# Altium schematic sheet Mechalino_Second_Layer.SchDoc(Mechalino_Second_Layer)
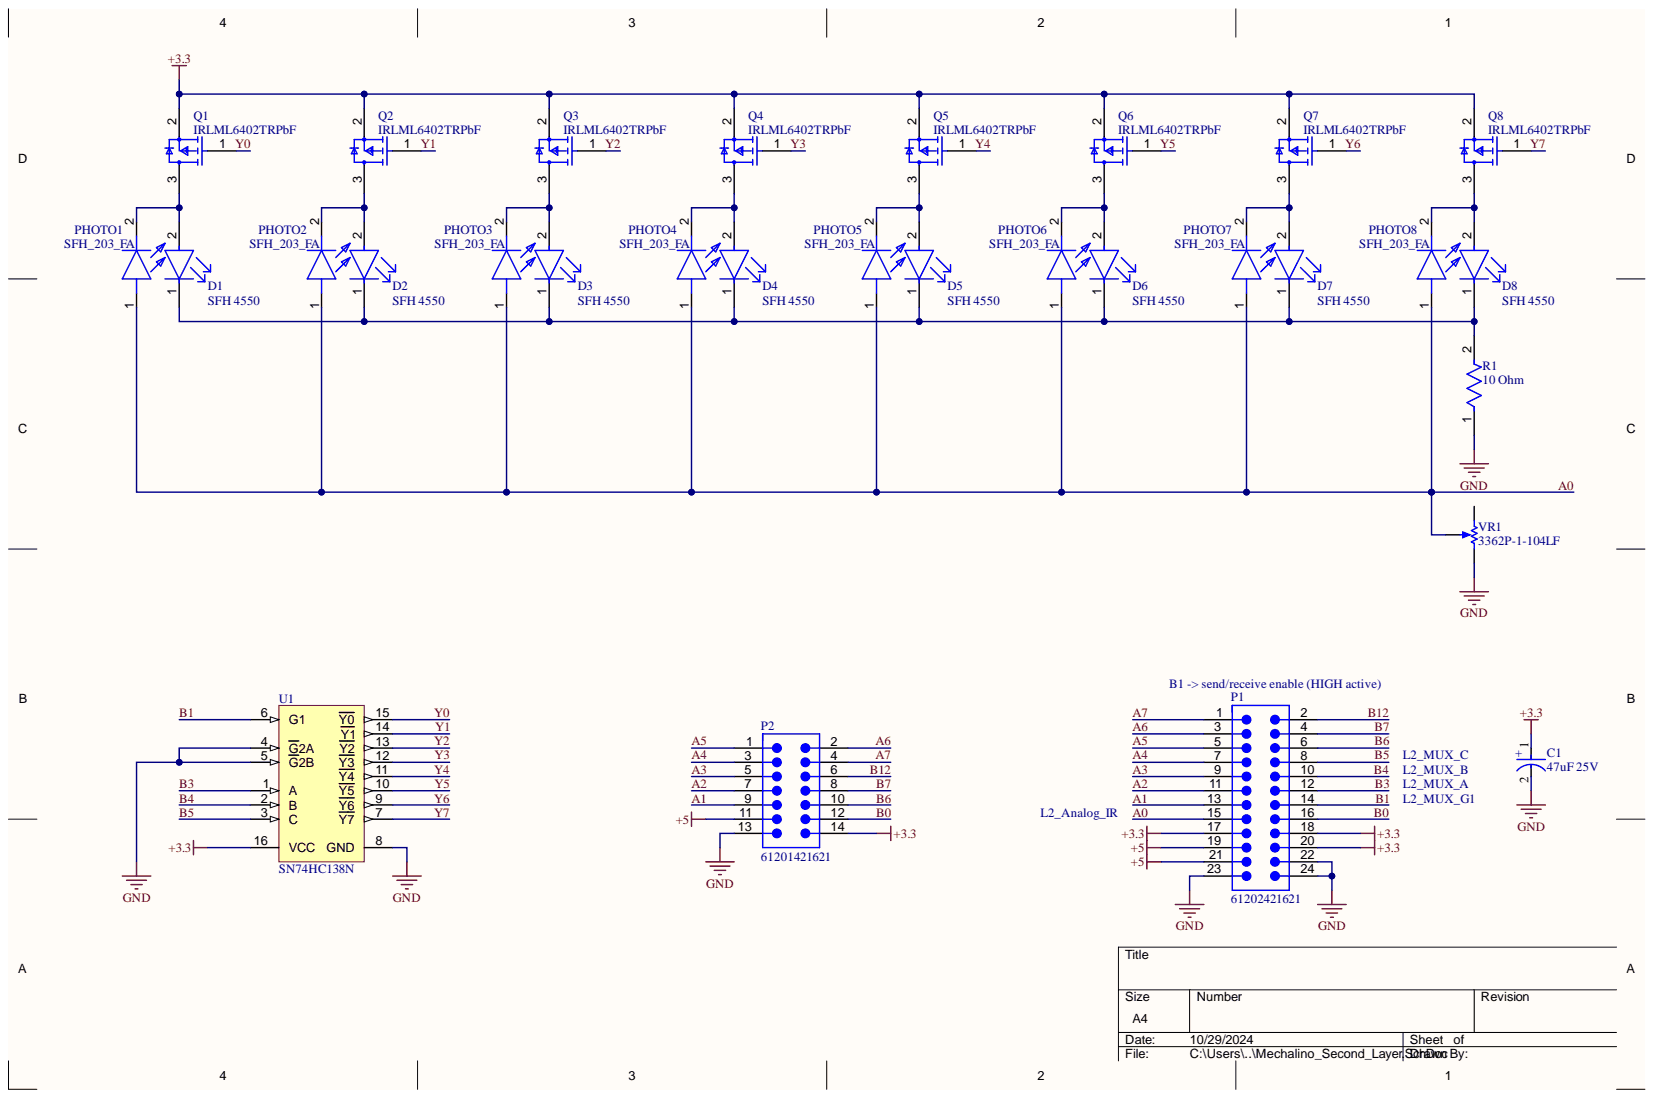
[diagram p0: Mechalino_Second_Layer.SchDoc]

## Parts
C1  47uF 25V  Capacitance: 47uF  [FP-FKD-MFG]
D1  SFH 4550  [FP-SFH_4550-MFG]
D2  SFH 4550  [FP-SFH_4550-MFG]
D3  SFH 4550  [FP-SFH_4550-MFG]
D4  SFH 4550  [FP-SFH_4550-MFG]
D5  SFH 4550  [FP-SFH_4550-MFG]
D6  SFH 4550  [FP-SFH_4550-MFG]
D7  SFH 4550  [FP-SFH_4550-MFG]
D8  SFH 4550  [FP-SFH_4550-MFG]
P1  61202421621  [61202421621]
P2  61201421621  [61201421621]
PHOTO1  SFH_203_FA  [SFH203FA]
PHOTO2  SFH_203_FA  [SFH203FA]
PHOTO3  SFH_203_FA  [SFH203FA]
PHOTO4  SFH_203_FA  [SFH203FA]
PHOTO5  SFH_203_FA  [SFH203FA]
PHOTO6  SFH_203_FA  [SFH203FA]
PHOTO7  SFH_203_FA  [SFH203FA]
PHOTO8  SFH_203_FA  [SFH203FA]
Q1  IRLML6402TRPbF  [FP-Micro3-MFG]
Q2  IRLML6402TRPbF  [FP-Micro3-MFG]
Q3  IRLML6402TRPbF  [FP-Micro3-MFG]
Q4  IRLML6402TRPbF  [FP-Micro3-MFG]
Q5  IRLML6402TRPbF  [FP-Micro3-MFG]
Q6  IRLML6402TRPbF  [FP-Micro3-MFG]
Q7  IRLML6402TRPbF  [FP-Micro3-MFG]
Q8  IRLML6402TRPbF  [FP-Micro3-MFG]
R1  10 Ohm  [FP-ESR25-IPC_B]
U1  SN74HC138N  [N0016A]
VR1  3362P-1-104LF  [BOUR-3362P]

## Nets
+3.3: C1.1 P1.17 P1.18 P1.20 P2.14 Q1.2 Q2.2 Q3.2 Q4.2 Q5.2 Q6.2 Q7.2 Q8.2 U1.16(VCC)
+5: P1.19 P1.21 P2.11
GND: C1.2 P1.22 P1.23 P1.24 P2.13 R1.1 U1.4(G2A) U1.5(G2B) U1.8(GND) VR1.3
A0: P1.15 PHOTO1.1 PHOTO2.1 PHOTO3.1 PHOTO4.1 PHOTO5.1 PHOTO6.1 PHOTO7.1 PHOTO8.1 VR1.2
A1: P1.13 P2.9
A2: P1.11 P2.7
A3: P1.9 P2.5
A4: P1.7 P2.3
A5: P1.5 P2.1
A6: P1.3 P2.2
A7: P1.1 P2.4
B0: P1.16 P2.12
B1: P1.14 U1.6(G1)
B3: P1.12 U1.1(A)
B4: P1.10 U1.2(B)
B5: P1.8 U1.3(C)
B6: P1.6 P2.10
B7: P1.4 P2.8
B12: P1.2 P2.6
Y0: Q1.1 U1.15(Y0)
Y1: Q2.1 U1.14(Y1)
Y2: Q3.1 U1.13(Y2)
Y3: Q4.1 U1.12(Y3)
Y4: Q5.1 U1.11(Y4)
Y5: Q6.1 U1.10(Y5)
Y6: Q7.1 U1.9(Y6)
Y7: Q8.1 U1.7(Y7)
NetD1_1: D1.1 D2.1 D3.1 D4.1 D5.1 D6.1 D7.1 D8.1 R1.2
NetD1_2: D1.2 PHOTO1.2 Q1.3
NetD2_2: D2.2 PHOTO2.2 Q2.3
NetD3_2: D3.2 PHOTO3.2 Q3.3
NetD4_2: D4.2 PHOTO4.2 Q4.3
NetD5_2: D5.2 PHOTO5.2 Q5.3
NetD6_2: D6.2 PHOTO6.2 Q6.3
NetD7_2: D7.2 PHOTO7.2 Q7.3
NetD8_2: D8.2 PHOTO8.2 Q8.3

Not connected: VR1 1

## Library data of the parts
C1 = CMP-05427-000093-1: CAP ALUM 47UF 20% 25V SMD; Dielectric Material: Aluminium; ESR (Equivalent Series Resistance): 360mR; Leakage Current: 3uA; Life (Hours): 2000Hour; Polarity: Polar; Ripple Current: 240mA; Case/Package: Radial; Component Kind: Standard; Construction: Cylindrical; Contact Plating: Tin; Diameter: 6.3mm; Height: 5.8mm; Height - Seated (Max): 0.24inch; Impedance: 360mR; Lead Free: Lead Free; Length: 5.8mm; Library Name: Altium Content Vault; Max Operating Temperature: 105°C; Min Operating Temperature: -55°C; Mount: Surface Mount; Number of Pins: 2; Package Quantity: 1000; Packaging: Tape and Reel; Radiation Hardening: No; REACH SVHC: No SVHC; Ripple Current (AC): 240mA; RoHS Compliant: Yes; Series: FK; Termination: SMD/SMT; Tolerance: 20%; Voltage: 25V; Voltage Rating: 25V; Voltage Rating (DC): 25V; Width: 7.8mm
D1-D8 = CMP-62016-000011-1: EMITTER IR 860NM 100MA RADIAL; Manufacturer: Osram Opto; Colour: Infrared; Forward Voltage: 1.5V; Led Orientation: Straight; Reverse Voltage: 5V; Viewing Angle: 6°; Automotive: No; Category: Diode; Component Kind: Standard; ComponentLink2Description: Datasheet; ComponentLink2URL: https://dammedia.osram.info/media/resource/hires/osram-dam-5580407/SFH%204550_EN.pdf; Device Class L1: Optoelectronics; Device Class L2: LEDs; Device Class L3: unset; Digikey Description: EMITTER IR 860NM 100MA RADIAL; Forward Current: 100mA; Lead Free: Yes; Library Name: Altium Content Vault; Manufacturer Part Number: SFH 4550; Mouser Description: Infrared Emitters - High Power Infrared 850 nm HALF ANGLE +/-3DEG; Octopart: https://octopart.com/sfh+4550-osram+opto-55410894; Package: SFH 4550; Peak Emmision Wavelength: 860nm; RoHS: TRUE; Temperature: -40°C to +100°C; Temperature Range High: +100°C; Temperature Range Low: -40°C
P1 = CMP-1502-00011-2: NexarPartId: 32855474; Connector Type: Male Pin; Contact Resistance: 20mΩ; Current Rating: 3A; Gender: Male; Insulation Resistance: 1GΩ; Number of Contacts: 24; Operating Supply Voltage: 250V; Pitch: 2.54mm; Color: Black; Component Kind: Standard; Contact Material: Copper; Contact Plating: Gold; Insulation Material: PBT; Lead Pitch: 2.54mm; Length: 38.14mm; Library Name: Altium Content Vault; Max Operating Temperature: 105°C; Min Operating Temperature: -40°C; Mount: Through Hole; Number of Pins: 24; Number of Positions: 24; Number of Rows: 2; Orientation: Vertical; REACH SVHC: Unknown; RoHS: Compliant; Row Spacing: 2.54mm; Termination: Solder
P2 = CMP-1502-00008-2: NexarPartId: 26603596; Connector Type: Male Pin; Contact Resistance: 20mΩ; Current Rating: 3A; Gender: Male; Insulation Resistance: 1GΩ; Number of Contacts: 14; Operating Supply Voltage: 250V; Pitch: 2.54mm; Color: Black; Component Kind: Standard; Contact Material: Copper; Contact Plating: Gold; Height: 9.25mm; Insulation Material: PBT; Lead Pitch: 2.54mm; Length: 25.44mm; Library Name: Altium Content Vault; Max Operating Temperature: 105°C; Min Operating Temperature: -40°C; Mount: Through Hole; Number of Pins: 14; Number of Positions: 14; Number of Rows: 2; Orientation: Vertical; REACH SVHC: Unknown; RoHS: Compliant; Row Spacing: 2.54mm; Termination: Solder; Voltage Rating: 250V
PHOTO1-PHOTO8 = SFH_203_FA: PHOTO; Manufacturer_Name: ams OSRAM; Manufacturer_Part_Number: SFH 203 FA; Component Kind: Standard; Datasheet Link: https://datasheet.datasheetarchive.com/originals/distributors/DKDS41/DSANUWW0036828.pdf; Height: 8.9mm; Mouser Part Number: 720-SFH203FA; Mouser Price/Stock: https://www.mouser.co.uk/ProductDetail/OSRAM-Opto-Semiconductors/SFH-203-FA?qs=K5ta8V%252BWhtaq1pinUuBcnA%3D%3D
Q1-Q8 = CMP-32901-000130-1: MOSFET P-CH 20V 3.7A SOT-23; Manufacturer: Infineon; Continuous Drain Current: -3.7A; Depletion Mode: False; Drain To Source Breakdown Voltage: -20V; Drain To Source Resistance: 0.05Ω; Gate Charge At Vgs: 8nC @ -5V; Gate To Source Voltage: 12V; Input Capacitace At Vds: 633pF @ -10V; Max Forward Diode Voltage: -1.2V; Max Junction Temp: +150°C; Number Of Channels: 1; Power Dissipation: 1.3W; Pulse Drain Current: -22A; Reverse Recovery Charge: 11nC; Reverse Recovery Time: 29ns; Rthja Max: 75°C/W; Standoff Height: 0.01mm; Threshold Vgs: -0.55V; Threshold Vgs Min: -0.4V; Turn Off Delay Time: 588ns; Turn On Delay Time: 350ns; Automotive: No; Category: Trans; Component Kind: Standard; ComponentLink2Description: Datasheet; ComponentLink2URL: https://www.infineon.com/dgdl/irlml6402pbf.pdf?fileId=5546d462533600a401535668d5c2263c; Device Class L1: Discrete Semiconductors; Device Class L2: Transistors; Device Class L3: MOSFETs; Digikey Description: MOSFET P-CH 20V 3.7A SOT-23; Drain To Source Voltage: -20V; Footprint Url: http://irf.com/package/outline/po_micro3.pdf; Height: 1.12mm; Ipc Land Pattern Name: SOT95P237X100-3; Lead Free: Yes; Library Name: Altium Content Vault; Manufacturer Part Number: IRLML6402TRPBF; Number Of P Channels: 1; Octopart: https://octopart.com/irlml6402trpbf-infineon-65874989; Package: Micro3; RoHS: TRUE; Technology: MOSFET; Temperature: -55°C to +150°C; Temperature Range High: +150°C; Temperature Range Low: -55°C; Threshold Vgs Max: -1.2V
R1 = CMP-08839-008971-1: 10Ω ±5% 0.66W 1210 Anti-Surge Chip Resistor AEC-Q200; Max Power Dissipation: 500mW; Resistance: 10R; Temperature Coefficient: 200ppm/°C; Case Code (Imperial): 1210; Case Code (Metric): 3225; Case/Package: 1210; Component Kind: Standard; Features: Automotive AEC-Q200; Height: 0.026inch; Length: 0.126inch; Library Name: Altium Content Vault; Max Operating Temperature: 155°C; Min Operating Temperature: -55°C; Number of Terminations: 2; Package Quantity: 1; Packaging: Tape and Reel; Resistor Type: Thick Film; RoHS Compliant: Yes; Series: ESR; Tolerance: 5%; Width: 0.098inch
U1 = CMP-1633-00627-2: 3-Line To 8-Line Decoders/Demultiplexers, N0016A, TUBE; Manufacturer: Texas Instruments; Bits(#): 8; Channels(#): 1; Configuration: 3：8; F @ Nom Voltage(Max)(Mhz): 28; Function: Decoder/Demultiplexer; ICC @ Nom Voltage(Max)(mA): 0.08; Input Type: LVTTL/CMOS; Output Drive (IOL/IOH)(Max)(mA): 5.2/-5.2; Output Type: CMOS; Rating: Catalog; Schmitt Trigger: No; Technology Family: HC; tpd @ Nom Voltage(Max)(ns): 45; VCC(Max)(V): 6; VCC(Min)(V): 2; Voltage(Nom)(V): 3.3,5; Code_JEDEC: MS-001; Component Kind: Standard; DatasheetVersion: SCLS107E; Generic Part Number: SN74HC138; Library Name: Altium Content Vault; Operating Temperature Range(C): -40 to 85; PackageReference: N0016A; Type: Standard
VR1 = CMP-1747-00003-1: Square Trimpot(R) Trimming Potentiometer, 100 KOhm, 300 V, -55 to 125 degC, 3-Pin THD, RoHS, Tube; Case\Package: Axial; Power Rating: 250 mW; Resistance: 1 mohm; Tolerance: 1%; Voltage Rating: 250 V; Component Kind: Standard; ComponentLink1Description: Manufacturer URL; ComponentLink1URL: http://www.bourns.com/; ComponentLink2Description: Datasheet; ComponentLink2URL: http://www.bourns.com/docs/Product-Datasheets/3362.pdf; DatasheetVersion: May-16; Length: 0.248 inch; Library Name: Altium Content Vault; Max Operating Temperature: 155 degC; Min Operating Temperature: -55 degC; Mount: Through Hole; Package Quantity: 200; PackageDescription: 3-Pin Through Hole Device, Body 6.6 x 6.99 mm, Pitch 2.54 mm; PackageReference: 3326P; Packaging: Bulk; RoHSCompliant: true
